# Altium schematic sheet LO_sys.SchDoc(LO_sys)
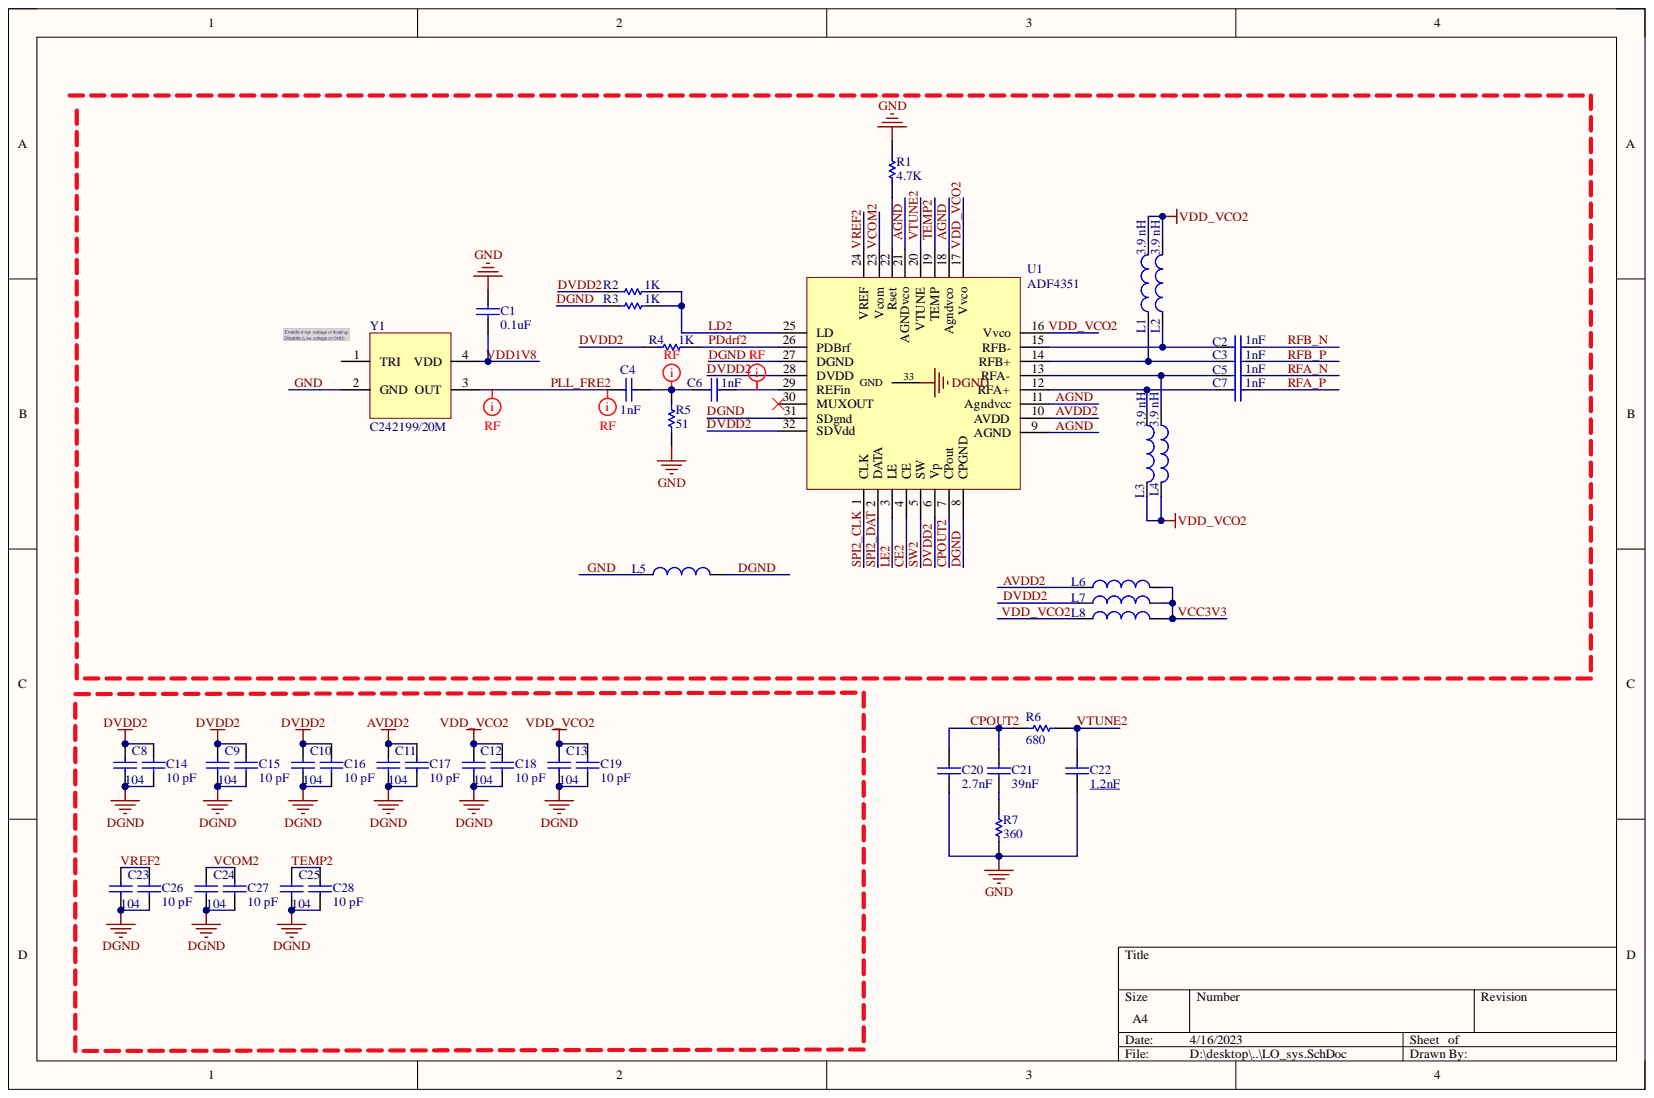
[diagram p0: LO_sys.SchDoc]

## Parts
C1  0.1uF  [0402C]
C2  1nF  [0402C]
C3  1nF  [0402C]
C4  1nF  [0402C]
C5  1nF  [0402C]
C6  1nF  [0402C]
C7  1nF  [0402C]
C8  104  [0402C]
C9  104  [0402C]
C10  104  [0402C]
C11  104  [0402C]
C12  104  [0402C]
C13  104  [0402C]
C14  10 pF  [0402C]
C15  10 pF  [0402C]
C16  10 pF  [0402C]
C17  10 pF  [0402C]
C18  10 pF  [0402C]
C19  10 pF  [0402C]
C20  2.7nF  [0402C]
C21  39nF  [0402C]
C22  1.2nF  [0402C]
C23  104  [0402C]
C24  104  [0402C]
C25  104  [0402C]
C26  10 pF  [0402C]
C27  10 pF  [0402C]
C28  10 pF  [0402C]
L1  3.9 nH  [0402_L]
L2  3.9 nH  [0402_L]
L3  3.9 nH  [0402_L]
L4  3.9 nH  [0402_L]
L5  (no value)  [0603L]
L6  (no value)  [0603L]
L7  (no value)  [0603L]
L8  (no value)  [0603L]
R1  4.7K  [0402R]
R2  1K  [0402R]
R3  1K  [0402R]
R4  1K  [0402R]
R5  51  [0402R]
R6  680  [0402R]
R7  360  [0402R]
U1  ADF4351  [QFN32_RHM (DATASHEET)]
Y1  C242199/20M  [BT0507B]

## Nets
AGND: U1.9(AGND) U1.11(Agndvcc) U1.18(Agndvco) U1.21(AGNDvco)
AVDD2: C11.2 C17.2 L6.1 U1.10(AVDD)
CE2: U1.4(CE)
CPOUT2: C20.2 C21.2 R6.1 U1.7(CPout)
DGND: C8.1 C9.1 C10.1 C11.1 C12.1 C13.1 C14.1 C15.1 C16.1 C17.1 C18.1 C19.1 C23.1 C24.1 C25.1 C26.1 C27.1 C28.1 L5.2 R3.1 U1.8(CPGND) U1.27(DGND) U1.31(SDgnd) U1.33
DVDD2: C8.2 C9.2 C10.2 C14.2 C15.2 C16.2 L7.1 R2.1 R4.1 U1.6(Vp) U1.28(DVDD) U1.32(SDVdd)
GND: C1.2 C20.1 C22.1 L5.1 R1.2 R5.1 R7.1 Y1.2(GND)
LD2: R2.2 R3.2 U1.25(LD)
LE2: U1.3(LE)
PDdrf2: R4.2 U1.26(PDBrf)
PLL_FRE2: C4.1 Y1.3(OUT)
RFA_N: C5.2
RFA_P: C7.2
RFB_N: C2.2
RFB_P: C3.2
SPI2_CLK: U1.1(CLK)
SPI2_DAT: U1.2(DATA)
SW2: U1.5(SW)
TEMP2: C25.2 C28.2 U1.19(TEMP)
VCC3V3: L6.2 L7.2 L8.2
VCOM2: C24.2 C27.2 U1.23(Vcom)
VDD1V8: C1.1 Y1.4(VDD)
VDD_VCO2: C12.2 C13.2 C18.2 C19.2 L1.2 L2.2 L3.2 L4.2 L8.1 U1.16(Vvco) U1.17(Vvco)
VREF2: C23.2 C26.2 U1.24(VREF)
VTUNE2: C22.2 R6.2 U1.20(VTUNE)
NetC2_1: C2.1 L2.1 U1.15(RFB-)
NetC3_1: C3.1 L1.1 U1.14(RFB+)
NetC4_2: C4.2 C6.1 R5.2
NetC5_1: C5.1 L4.1 U1.13(RFA-)
NetC6_2: C6.2 U1.29(REFin)
NetC7_1: C7.1 L3.1 U1.12(RFA+)
NetC21_1: C21.1 R7.2
NetR1_1: R1.1 U1.22(Rset)

Not connected: U1 30(MUXOUT); Y1 1(TRI)

## Library data of the parts
C1-C28 = Cap: GNSS; Value: 100pF; Component Kind: Standard; LatestRevisionDate: 17-Jul-2002; LatestRevisionNote: Re-released for DXP Platform.; PackageDescription: Radial Cap, Thru-Hole; 2 Leads; 0.3 in Pin Spacing; PackageReference: RAD-0.3; Published: 8-Jun-2000; Signal Integrity: Cap; Simulation: CAP; Publisher: Altium Limited
  C1: Library Name: Miscellaneous Devices.IntLib
  C2-C28: Library Name: GPS_Ant.SCHLIB
L1-L4 = Inductor: GNSS; Code_IEC: 1005; Value: 10mH; Code_JEITA: 0402; Component Kind: Standard; LatestRevisionDate: 29-May-2009; LatestRevisionNote: IPC-7351 Footprint Added.; Library Name: GPS_Ant.SCHLIB; PackageDescription: Chip Inductor; PackageReference: 0402-A; Published: 8-Jun-2000; Signal Integrity: Inductor; Simulation: INDUCTOR; Publisher: Altium Limited
L5-L8 = Inductor: GNSS; Code_IEC: 1005; Code_JEITA: 0402; Component Kind: Standard; LatestRevisionDate: 29-May-2009; LatestRevisionNote: IPC-7351 Footprint Added.; Library Name: Miscellaneous Devices.IntLib; PackageDescription: Chip Inductor; PackageReference: 0402-A; Published: 8-Jun-2000; Signal Integrity: Inductor; Simulation: INDUCTOR; Publisher: Altium Limited
R1-R7 = Res1: GNSS; Component Kind: Standard; LatestRevisionDate: 17-Jul-2002; LatestRevisionNote: Re-released for DXP Platform.; Library Name: GPS_Ant.SCHLIB; PackageDescription: Axial Device, Thru-Hole; 2 Leads; 0.3 in Pin Spacing; PackageReference: AXIAL-0.3; Published: 8-Jun-2000; Signal Integrity: Res; Simulation: RESISTOR; Publisher: Altium Limited
Y1 = C242199: GNSS; Component Kind: Standard; Library Name: TR_LIB.SchLib
U1 = ADF4351: Component Kind: Standard; Library Name: GPS_Ant.SCHLIB
